# Altium schematic sheet Sheet1.SchDoc
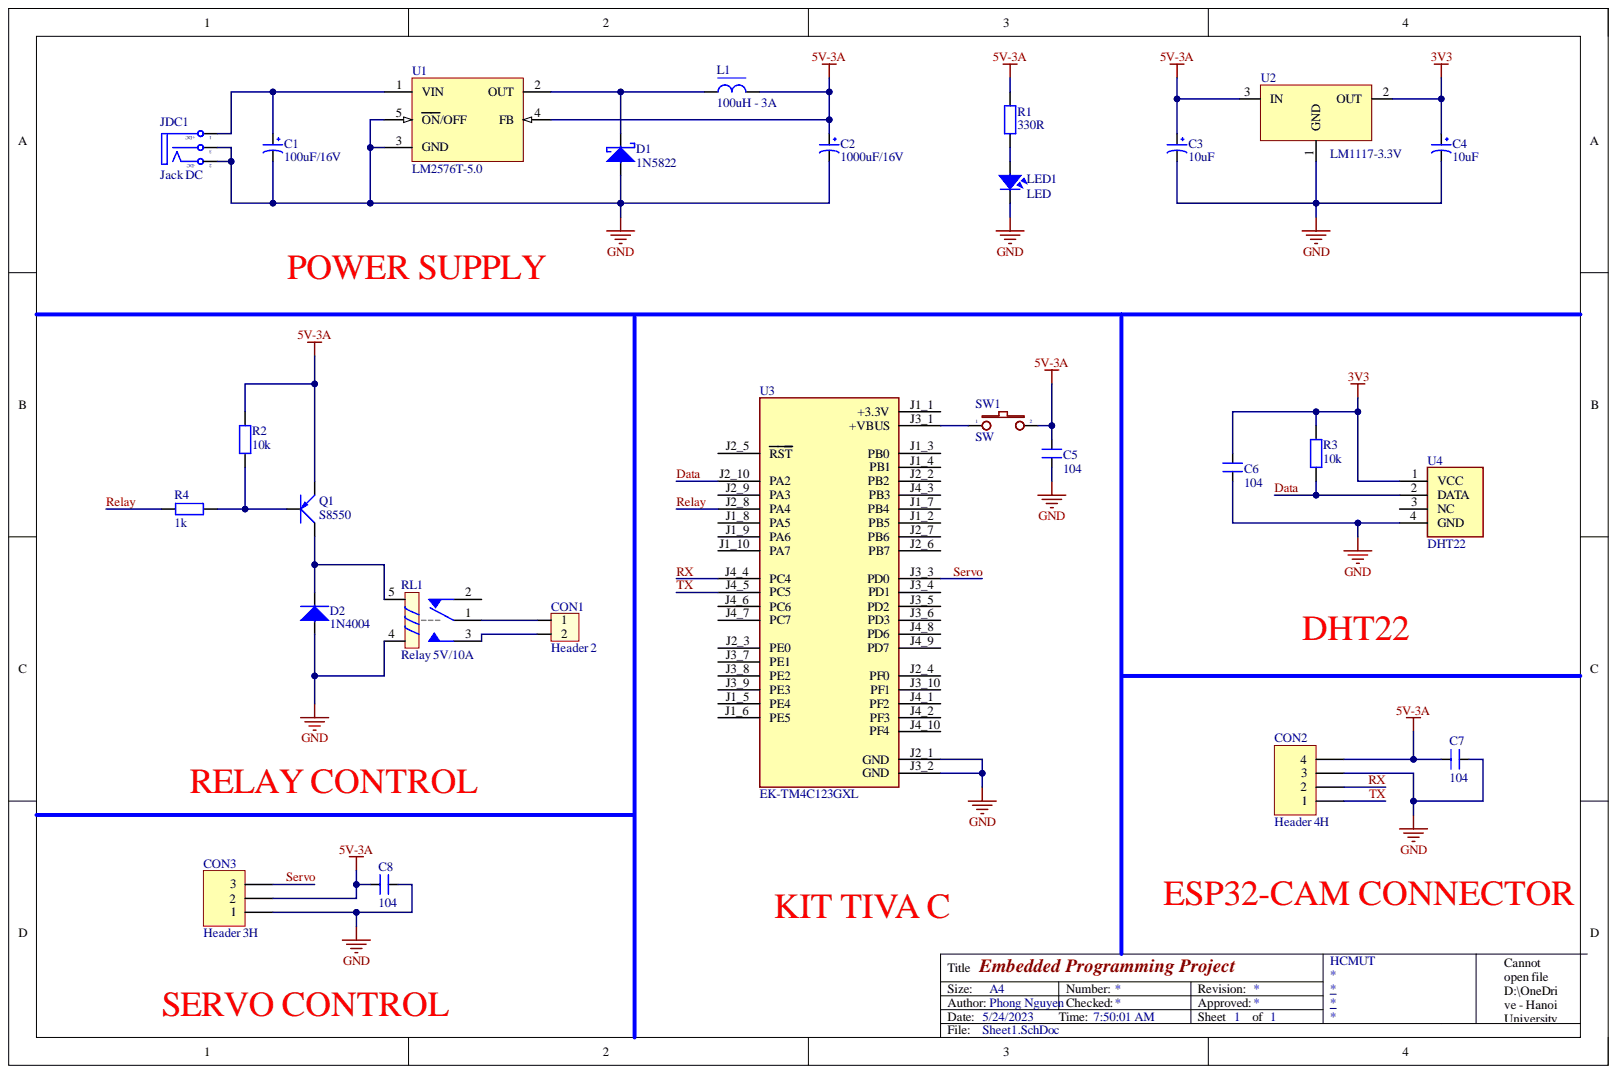
[diagram p0: Sheet1.SchDoc]

## Parts
C1  100uF/16V  [CP1.5/3.4]
C2  1000uF/16V  [CP1.5/3.4]
C3  10uF  [CP1/2]
C4  10uF  [CP1/2]
C5  104  [CN2]
C6  104  [CN2]
C7  104  [CN2]
C8  104  [CN2]
CON1  Header 2  [HED2]
CON2  Header 4H  [HDR1X4H]
CON3  Header 3H  [HDR1X3H]
D1  1N5822  [DO-201AD]
D2  1N4004  [DO-41]
JDC1  Jack DC  [DC-IN]
L1  100uH - 3A  [L-DIP-M-15x7]
LED1  LED  [LED-3]
Q1  S8550  [QTO-92]
R1  330R  [RES-1/4W-SMALL]
R2  10k  [RES-1/4W-SMALL]
R3  10k  [RES-1/4W-SMALL]
R4  1k  [RES-1/4W-SMALL]
RL1  Relay 5V/10A  [RL_SPDT_10A]
SW1  SW  [SW-DIP1]
U1  LM2576T-5.0  [LM2576t]
U2  LM1117-3.3V  [TO220-123]
U3  EK-TM4C123GXL  [MODULE_EK-TM4C123GXL]
U4  DHT22  [DHT22]

## Nets
3V3: C4.1 C6.1 R3.2 U2.2 U4.1(VCC)
5V-3A: C2.1 C3.1 C5.2 C7.2 C8.1 CON2.4 CON3.2 L1.2 Q1.1 R1.2 R2.2 SW1.2 U1.4(FB) U2.3
GND: C1.2 C2.2 C3.2 C4.2 C5.1 C6.2 C7.1 C8.2 CON2.3 CON3.1 D1.1 D2.1 JDC1.2 JDC1.3 LED1.2 RL1.4 U1.3(GND) U1.5(ON/OFF) U2.1 U3.J2_1(GND) U3.J3_2(GND) U4.4(GND)
Data: R3.1 U3.J2_10(PA2) U4.2(DATA)
Relay: R4.1 U3.J2_8(PA4)
RX: CON2.2 U3.J4_4(PC4)
Servo: CON3.3 U3.J3_3(PD0)
TX: CON2.1 U3.J4_5(PC5)
NetC1_1: C1.1 JDC1.1 U1.1(VIN)
NetCON1_1: CON1.1 RL1.1
NetCON1_2: CON1.2 RL1.3
NetD1_2: D1.2 L1.1 U1.2(OUT)
NetD2_2: D2.2 Q1.3 RL1.5
NetLED1_1: LED1.1 R1.1
NetQ1_2: Q1.2 R2.1 R4.2
NetSW1_1: SW1.1 U3.J3_1(+VBUS)

Not connected: RL1 2; U3 J1_1(+3.3V) J1_2(PB5) J1_3(PB0) J1_4(PB1) J1_5(PE4) J1_6(PE5) J1_7(PB4) J1_8(PA5) J1_9(PA6) J1_10(PA7) J2_2(PB2) J2_3(PE0) J2_4(PF0) J2_5(RST) J2_6(PB7) J2_7(PB6) J2_9(PA3) J3_4(PD1) J3_5(PD2) J3_6(PD3) J3_7(PE1) J3_8(PE2) J3_9(PE3) J3_10(PF1) J4_1(PF2) J4_2(PF3) J4_3(PB3) J4_6(PC6) J4_7(PC7) J4_8(PD6) J4_9(PD7) J4_10(PF4); U4 3(NC)

## Library data of the parts
C1 = CP3: Cap 100uF/35V - 470uF/16V; Description 1: 1000uF/6,3V - 470uF/16V - 330uF - 25V; Description 2: 220uF/35V - 100uF/50V - 63V - 4,7uF/200V; Description 3: 22uF => 33uF100V - 3,3uF => 4,7uF/250V; Description 4: 2,2uF =>3,3uF/350 - 2,2uF/400V - 1uF/450V; Value: 100uF/35V/50V; Component Kind: Standard; Library Name: KhanhBK_Library_4.IntLib
C2 = CP4: Cap 1000uF/16V - 220uF/50V; Description 1: 2200uF-3300uF/6,3V - 1000uF-2200uF/10V; Description 2: 1000uF/16V - 470uF => 1000uF/25V - 100uF/100V; Description 3: 330uF>470uF/35V_220uF>470uF/50V_10uF>22uF/250V; Description 4: 220uF => 330uF/63V - 10uF =>33uF/160 - 200V; Description 5: 4,7uF>10uF/250V_3,3uF>10uF/400V - 2,2uF>4,7uF/450V; Component Kind: Standard; Value: 1000uF/16V; Library Name: KhanhBK_Library_4.IntLib
C3 C4 = CP1: Cap P  0,1uF < 22uF , 16 - 50V; Description 1: 10 => 220uF/6,3V/10V - 10uF => 100uF/16V; Description 2: 0,1uF => 33uF/50V - 10uF => 22uF/63V; Description 3: 0,47uF => 10uF/100V; Value: 10uF/50V; Component Kind: Standard; Library Name: KhanhBK_Library_4.IntLib
C5-C8 = CN2: Cap np 104 => 105pF; Value: 0.1uF; Component Kind: Standard; Library Name: KhanhBK_Library_4.IntLib
CON1 = Hed2: Header 2 (Green); Component Kind: Standard; Library Name: Devzone_SCHLib.SchLib; Value: Header 2
CON2 = Header 4H: Header, 4-Pin, Right Angle; Component Kind: Standard; LatestRevisionDate: 17-Jul-2002; LatestRevisionNote: Re-released for DXP Platform.; Library Name: Devzone_SCHLib.SchLib; Signal Integrity: Connector; Publisher: Altium Limited
CON3 = Header 3H: Header, 3-Pin, Right Angle; Component Kind: Standard; LatestRevisionDate: 17-Jul-2002; LatestRevisionNote: Re-released for DXP Platform.; Library Name: Devzone_SCHLib.SchLib; Signal Integrity: Connector; Publisher: Altium Limited
D1 = Diode Schottky: Part Number: LED 3 ly Single Colour; Component Kind: Standard; Description: Diode Schottky; Library Name: KhanhBK_Library_4.IntLib
D2 = Diode: Component Kind: Standard; Library Name: KhanhBK_Library_4.IntLib
JDC1 = DCIN: Jac DC input; Value: Jac DC; Component Kind: Standard; Library Name: KhanhBK_SCH_Library_2.SchLib
L1 = L-DIP-1: Inductor; Value: 150 mH; Component Kind: Standard; Library Name: KhanhBK_SCH_Library_1.SchLib
LED1 = LED: LED Single colour Green and Red; Value: LED 3 ly Single Colour; Component Kind: Standard; Library Name: KhanhBK_SCH_Library_2.SchLib
Q1 = PNP_EBC: P 600mW, EBC; Value: C9015; Component Kind: Standard; Library Name: Devzone_SCHLib.SchLib
R1-R4 = RES 1/4W: Resistor 1/4W; Value: R1/4W - 1K; Component Kind: Standard; Library Name: KhanhBK_SCH_Library_2.SchLib
RL1 = Relay 1: Relay SPDT, 5VDC/10A 5 Pin; Value: Relay SPDT, 10A, 12V; Component Kind: Standard; Library Name: Devzone_SCHLib.SchLib
SW1 = SW2: Switch button 2 pin; Value: SW chip 2 pin; Component Kind: Standard; Library Name: KhanhBK_Library_3.IntLib
U1 = LM2576T-5.0: SIMPLE SWITCHER; 3A Step-Down Voltage Regulator; Manufacturer: National Semiconductor; PackageTopMarking: NSUZXYTT*LM2576T*-5.0 P+; PowerWise: N; Code_JEDEC: TO-220-AB; Component Kind: Standard; ComponentLink1Description: LM2576 Datasheet; ComponentLink1URL: http://www.national.com/ds/LM/LM2576.pdf; ComponentLink2Description: LM2576 Web Page; ComponentLink2URL: http://www.national.com/pf/LM/LM2576.html; ComponentLink3Description: T05A Package Page; ComponentLink3URL: http://www.national.com/packaging/folders/t05a.html; CompType: Switcher; DatasheetVersion: Aug-2004; DigiKeyPartNumber: LM2576T-5.0-ND; FirstPublished: 4-Dec-2008; Library Name: Devzone_SCHLib.SchLib; PackageDescription: TO-220, Molded, 5 Lead, Straight; PackageReference: T05A; PackageVersion: revJ, Jan-1992; PartNumber: LM2576T-5.0; PinCount: 5; RoHS: O; Publisher: Altium Limited
U2 = LM1117: 800mA Low-Dropout Linear Regulator; Component Kind: Standard; Website: http://group3i.net; Creator: Nguyen Ba Bien; Library Name: KhanhBK_SCH_Library_1.SchLib
U3 = EK-TM4C123GXL: ARMÂ® CortexÂ®-M4F Based MCU TM4C123G LaunchPadâ„¢ Evaluation Kit; MF: Texas Instruments; Availability: Warning; Check_prices: https://www.snapeda.com/parts/EK-TM4C123GXL/Texas%20Instruments/view-part/132968/?ref=eda; Component Kind: Standard; Library Name: EK-TM4C123GXL.SchLib; MP: EK-TM4C123GXL; Package: None; Price: None; Purchase-URL: https://pricing.snapeda.com/search/part/EK-TM4C123GXL/?ref=eda; SnapEDA_Link: https://www.snapeda.com/parts/EK-TM4C123GXL/Texas%20Instruments/view-part/132968/?ref=snap
U4 = DHT22: Capacitive-type humidity and temperature module/sensor; MF: Aosong Electronics; Availability: Not in stock; Check_prices: https://www.snapeda.com/parts/DHT22/Aosong+Electronics/view-part/?ref=eda; Component Kind: Standard; Library Name: DHT22.SchLib; MP: DHT22; Package: Package; Price: None; SnapEDA_Link: https://www.snapeda.com/parts/DHT22/Aosong+Electronics/view-part/?ref=snap
